# Altium schematic sheet Main.SchDoc
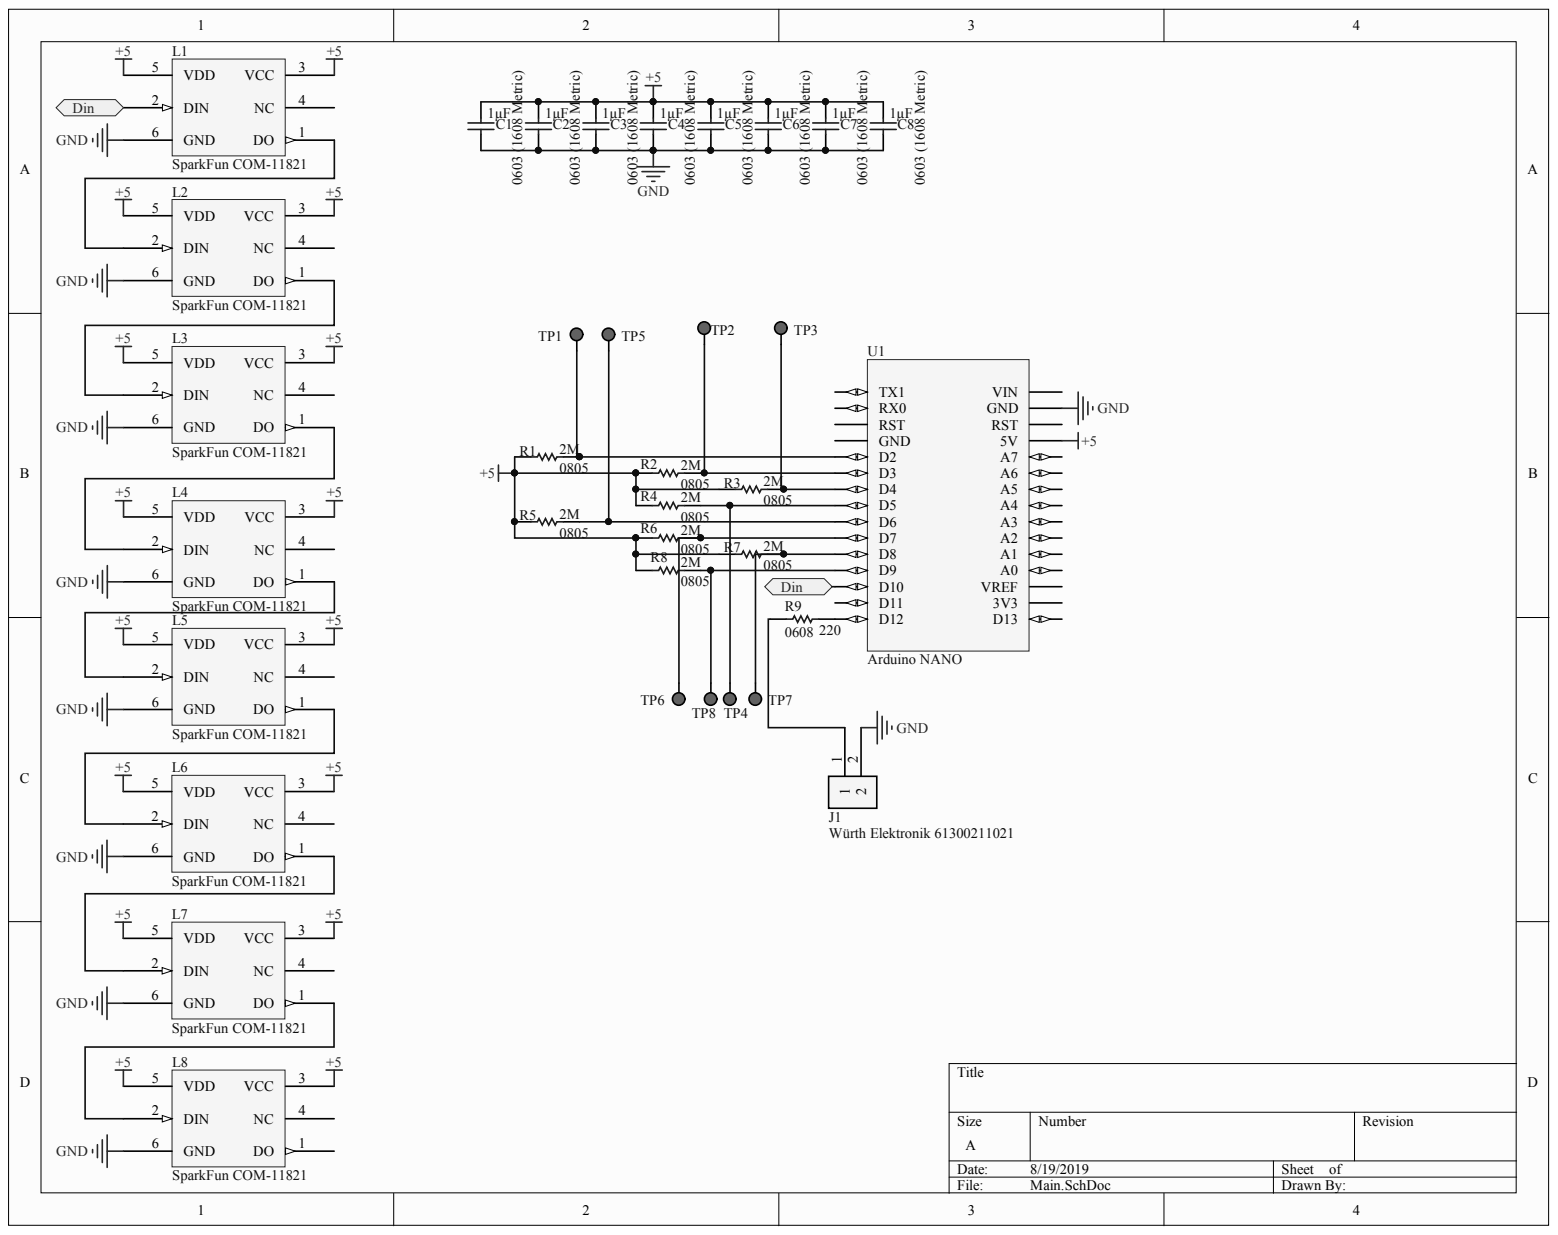
[diagram p0: Main.SchDoc]

## Parts
C1  Capacitance: 1µF  [PCB-lkmleyt60a11wkc2qm7q-1]  (hidden comment: Samsung CL10A105KP8NNNC)
C2  Capacitance: 1µF  Package / Case: 0603 (1608 Metric)  [PCB-lkmleyt60a11wkc2qm7q-1]  (hidden comment: Samsung CL10A105KP8NNNC)
C3  Capacitance: 1µF  Package / Case: 0603 (1608 Metric)  [PCB-lkmleyt60a11wkc2qm7q-1]  (hidden comment: Samsung CL10A105KP8NNNC)
C4  Capacitance: 1µF  Package / Case: 0603 (1608 Metric)  [PCB-lkmleyt60a11wkc2qm7q-1]  (hidden comment: Samsung CL10A105KP8NNNC)
C5  Capacitance: 1µF  Package / Case: 0603 (1608 Metric)  [PCB-lkmleyt60a11wkc2qm7q-1]  (hidden comment: Samsung CL10A105KP8NNNC)
C6  Capacitance: 1µF  Package / Case: 0603 (1608 Metric)  [PCB-lkmleyt60a11wkc2qm7q-1]  (hidden comment: Samsung CL10A105KP8NNNC)
C7  Capacitance: 1µF  Package / Case: 0603 (1608 Metric)  [PCB-lkmleyt60a11wkc2qm7q-1]  (hidden comment: Samsung CL10A105KP8NNNC)
C8  Capacitance: 1µF  Package / Case: 0603 (1608 Metric)  [PCB-lkmleyt60a11wkc2qm7q-1]  (hidden comment: Samsung CL10A105KP8NNNC)
J1  Würth Elektronik 61300211021  [PCB-t2j72m1yraok0w090vy8-1]
L1  SparkFun COM-11821  [PCB-1ur4ffa4apwrigk38oa4-1]
L2  SparkFun COM-11821  [PCB-1ur4ffa4apwrigk38oa4-1]
L3  SparkFun COM-11821  [PCB-1ur4ffa4apwrigk38oa4-1]
L4  SparkFun COM-11821  [PCB-1ur4ffa4apwrigk38oa4-1]
L5  SparkFun COM-11821  [PCB-1ur4ffa4apwrigk38oa4-1]
L6  SparkFun COM-11821  [PCB-1ur4ffa4apwrigk38oa4-1]
L7  SparkFun COM-11821  [PCB-1ur4ffa4apwrigk38oa4-1]
L8  SparkFun COM-11821  [PCB-1ur4ffa4apwrigk38oa4-1]
R1  Case/Package: 0805  Resistance: 2M  [RESC2013X50X30ML20T20]  (hidden comment: Vishay Dale CRCW08053K90FKEA)
R2  Case/Package: 0805  Resistance: 2M  [RESC2013X50X30ML20T20]  (hidden comment: Vishay Dale CRCW08053K90FKEA)
R3  Case/Package: 0805  Resistance: 2M  [RESC2013X50X30ML20T20]  (hidden comment: Vishay Dale CRCW08053K90FKEA)
R4  Case/Package: 0805  Resistance: 2M  [RESC2013X50X30ML20T20]  (hidden comment: Vishay Dale CRCW08053K90FKEA)
R5  Case/Package: 0805  Resistance: 2M  [RESC2013X50X30ML20T20]  (hidden comment: Vishay Dale CRCW08053K90FKEA)
R6  Case/Package: 0805  Resistance: 2M  [RESC2013X50X30ML20T20]  (hidden comment: Vishay Dale CRCW08053K90FKEA)
R7  Case/Package: 0805  Resistance: 2M  [RESC2013X50X30ML20T20]  (hidden comment: Vishay Dale CRCW08053K90FKEA)
R8  Case/Package: 0805  Resistance: 2M  [RESC2013X50X30ML20T20]  (hidden comment: Vishay Dale CRCW08053K90FKEA)
R9  0608  value 220  [PCB-i9f5vo4hvu8q9ebidz15-1]
TP1  SYM-d65b1e1746cb099c-7  [PCB-05qrves7qt31i6rf73jz-1]  (value not drawn)
TP2  SYM-d65b1e1746cb099c-7  [PCB-05qrves7qt31i6rf73jz-1]  (value not drawn)
TP3  SYM-d65b1e1746cb099c-7  [PCB-05qrves7qt31i6rf73jz-1]  (value not drawn)
TP4  SYM-d65b1e1746cb099c-7  [PCB-05qrves7qt31i6rf73jz-1]  (value not drawn)
TP5  SYM-d65b1e1746cb099c-7  [PCB-05qrves7qt31i6rf73jz-1]  (value not drawn)
TP6  SYM-d65b1e1746cb099c-7  [PCB-05qrves7qt31i6rf73jz-1]  (value not drawn)
TP7  SYM-d65b1e1746cb099c-7  [PCB-05qrves7qt31i6rf73jz-1]  (value not drawn)
TP8  SYM-d65b1e1746cb099c-7  [PCB-05qrves7qt31i6rf73jz-1]  (value not drawn)
U1  Arduino NANO  [PCB-kcq4ssidua0wf3ce18dn-1]

## Ports
DIN

## Nets
+5: C1.2 C2.2 C3.2 C4.2 C5.2 C6.2 C7.2 C8.2 L1.3(VCC) L1.5(VDD) L2.3(VCC) L2.5(VDD) L3.3(VCC) L3.5(VDD) L4.3(VCC) L4.5(VDD) L5.3(VCC) L5.5(VDD) L6.3(VCC) L6.5(VDD) L7.3(VCC) L7.5(VDD) L8.3(VCC) L8.5(VDD) R1.1 R2.1 R3.1 R4.1 R5.1 R6.1 R7.1 R8.1 U1.27(5V)
GND: C1.1 C2.1 C3.1 C4.1 C5.1 C6.1 C7.1 C8.1 J1.2 L1.6(GND) L2.6(GND) L3.6(GND) L4.6(GND) L5.6(GND) L6.6(GND) L7.6(GND) L8.6(GND) U1.29(GND)
NetJ1_1: J1.1 R9.1
NetL1_1: L1.1(DO) L2.2(DIN)
NetL1_2: L1.2(DIN) U1.13(D10) ; port DIN
NetL2_1: L2.1(DO) L3.2(DIN)
NetL3_1: L3.1(DO) L4.2(DIN)
NetL4_1: L4.1(DO) L5.2(DIN)
NetL5_1: L5.1(DO) L6.2(DIN)
NetL6_1: L6.1(DO) L7.2(DIN)
NetL7_1: L7.1(DO) L8.2(DIN)
NetR1_2: R1.2 TP1.1 U1.5(D2)
NetR2_2: R2.2 TP2.1 U1.6(D3)
NetR3_2: R3.2 TP3.1 U1.7(D4)
NetR4_2: R4.2 TP4.1 U1.8(D5)
NetR5_2: R5.2 TP5.1 U1.9(D6)
NetR6_2: R6.2 TP6.1 U1.10(D7)
NetR7_2: R7.2 TP7.1 U1.11(D8)
NetR8_2: R8.2 TP8.1 U1.12(D9)
NetR9_2: R9.2 U1.15(D12)

Not connected: L1 4(NC); L2 4(NC); L3 4(NC); L4 4(NC); L5 4(NC); L6 4(NC); L7 4(NC); L8 1(DO) 4(NC); U1 1(TX1) 2(RX0) 3(RST) 4(GND) 14(D11) 16(D13) 17(3V3) 18(VREF) 19(A0) 20(A1) 21(A2) 22(A3) 23(A4) 24(A5) 25(A6) 26(A7) 28(RST) 30(VIN)

## Library data of the parts
C1-C8 = CMP-1956d172a695c691-3: CL10 Series 1 uF 10 V ±10 % Tolerance X5R SMT Multilayer Ceramic Capacitor; Manufacturer: Samsung Electro-Mechanics; Vendor Part Number: 1276-1182-1-ND; Tolerance: ±10%; Vendor: Digikey; Voltage - Rated: 10V; Applications: General Purpose; Component Kind: Standard; Cost: 0.10; HelpURL: http://www.samsungsem.com/kr/support/product-search/mlcc/__icsFiles/afieldfile/2016/08/18/S_CL10A105KP8NNNC.pdf; Library Name: CommunityVault; Manufacturer PArt Number: CL10A105KP8NNNC; Mounting Type: Surface Mount, MLCC; Operating Temperature: -55°C ~ 85°C; Series: CL; Size / Dimension: 0.063" L x 0.032" W (1.60mm x 0.80mm); Temperature Coefficient: X5R; Thickness (Max): 0.035" (0.90mm)
  C1: Package / Case: 0603 (1608 Metric)
J1 = CMP-7939b1c60980a6fe-3: Board-To-Board Connector, Right Angle, WR-PHD Series, Through Hole, Header, 2, 2.54 mm; Gender: Male; Number of Contacts: 2; Component Kind: Standard; Contact Material: Copper; Contact Plating: Gold; Library Name: CommunityVault; Manufacturer Part Number: 61300211021; Mounting Style: Through Hole; OctopartID: 7939b1c60980a6fe; Octopart URL: http://octopart.com/61300211021-w%C3%BCrth+elektronik-32855519; REACH SVHC Compliance: No SVHC; RoHS: Compliant
L1-L8 = CMP-ba0777713b8065c0-3: Led - Smd Rgb (Ws2812); Component Kind: Standard; Library Name: CommunityVault; OctopartID: ba0777713b8065c0
R1-R8 = CMP-1857812-2: Res Thick Film 0805 3.9K Ohm 1% 0.125W(1/8W) ±100ppm/C Molded SMD Automotive Paper T/R; Manufacturer Part Number: RMCF0805FT2M05CT-ND; Case/Package (SI): 2012; Lead-Free Status: Lead Free; Voltage Rating (DC): 150.0 V; Component Kind: Standard; Library Name: CommunityVault; Mounting Style: Surface Mount; Number of Pins: 2; OctopartID: 0a1bc14e8327d5dc; Octopart Url: https://octopart.com/crcw08053k90fkea-vishay-39824474; Power Rating: 0.125 W; REACH SVHC Compliance: No SVHC; Resistance Tolerance: ±1%; RoHS: Compliant; Size-Height: 0.00045 m; Size-Length: 0.002 m; Size-Width: 0.00125 m
R9 = CMP-6721ab479138b8e6-1: Res, Auto, Thick Film, 220R, 5%, 0603; Manufacturer Part Number: CRGCQ0603J220R; Component Kind: Standard; Library Name: CommunityVault; Lifecycle Status: Not Listed by Manufacturer; OctopartID: 6721ab479138b8e6; Octopart URL: https://octopart.com/crgcq0603j220r-te+connectivity-91018111; Packaging: Cut Tape (CT); Resistance Tolerance: ±5%
TP1-TP8 = CMP-d65b1e1746cb099c-20: Raychem; SMT Circuit probe pad, 0603, 0R0 RCU; Component Kind: Standard; Library Name: CommunityVault
U1 = CMP-40a988ff651f9f6e-14: Component Kind: Standard; Description: arduino nano; Library Name: CommunityVault
